# Altium schematic sheet Data-Power.SchDoc
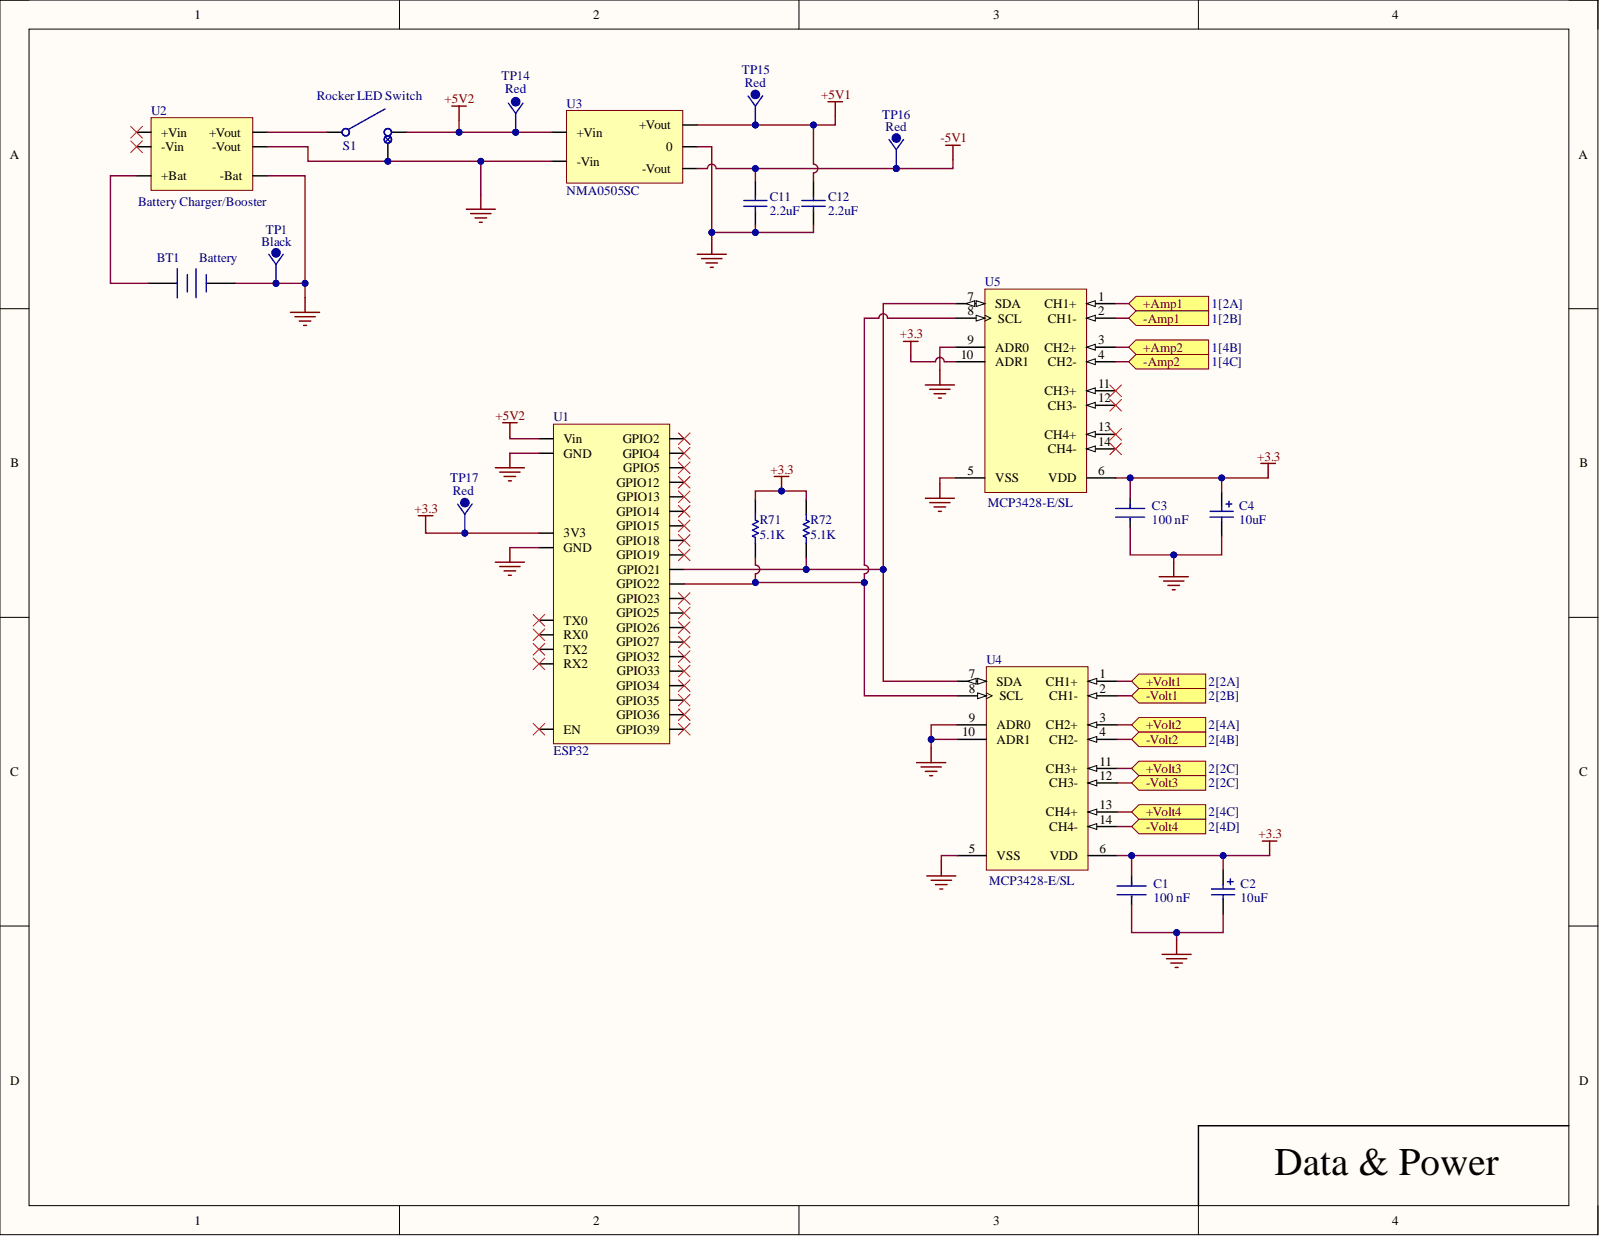
[diagram p0: Data-Power.SchDoc]

## Parts
BT1  Battery  [BAT-2]
C1  100nF  value 100 nF  [FP-CC0805-0_85-MFG]
C2  value 10uF  [0805 Tant Cap]  (hidden comment: TCTP1C106M8R)
C3  100nF  value 100 nF  [FP-CC0805-0_85-MFG]
C4  value 10uF  [0805 Tant Cap]  (hidden comment: TCTP1C106M8R)
C11  2.2uF  [CAPC2013X135X50ML10T20]
C12  2.2uF  [CAPC2013X135X50ML10T20]
R71  value 5.1K  [0805 Res]  (hidden comment: 5.1k Ohm)
R72  value 5.1K  [0805 Res]  (hidden comment: 5.1k Ohm)
S1  Rocker LED Switch  [SW]
TP1  Color: Black  [BlackTP]  (hidden comment: TP (Black))
TP14  Color: Red  [RedTP]  (hidden comment: TP (Red))
TP15  Color: Red  [RedTP]  (hidden comment: TP (Red))
TP16  Color: Red  [RedTP]  (hidden comment: TP (Red))
TP17  Color: Red  [RedTP]  (hidden comment: TP (Red))
U1  ESP32  [ESP32]
U2  Battery Charger/Booster  [Batt Chrg/Bst]
U3  DC DC Converter  [NMA0505SC]  (value not drawn)
U4  Manufacturer Part Number: MCP3428-E/SL  [SOIC-SL14_N]  (hidden comment: 4Ch ADC)
U5  Manufacturer Part Number: MCP3428-E/SL  [SOIC-SL14_N]  (hidden comment: 4Ch ADC)

## Ports
+Amp1, +Amp2, +Volt1, +Volt2, +Volt3, +Volt4, -Amp1, -Amp2, -Volt1, -Volt2, -Volt3, -Volt4

## Nets
+3.3: C1.1 C2.1 C3.1 C4.1 R71.2 R72.2 TP17.1 U1. U4.6(VDD) U5.6(VDD) U5.10(ADR1)
+5V1: C12.2 TP15.1 U3.
+5V2: S1. TP14.1 U1. U3.
-5V1: C11.2 TP16.1 U3.
GND: BT1.2 C1.2 C2.2 C3.2 C4.2 C11.1 C12.1 S1. TP1.1 U1. U2. U3. U4.5(VSS) U4.9(ADR0) U4.10(ADR1) U5.5(VSS) U5.9(ADR0)
NetS1: S1. U2.
NetU2: U2.
NetBT1_1: BT1.1 U2.
NetR71_1: R71.1 U1.39(GPIO22) U4.8(SCL) U5.8(SCL)
NetR72_1: R72.1 U1.42(GPIO21) U4.7(SDA) U5.7(SDA)
NetU4_1: U4.1(CH1+)
NetU4_2: U4.2(CH1-)
NetU4_3: U4.3(CH2+)
NetU4_4: U4.4(CH2-)
NetU4_11: U4.11(CH3+)
NetU4_12: U4.12(CH3-)
NetU4_13: U4.13(CH4+)
NetU4_14: U4.14(CH4-)
NetU5_1: U5.1(CH1+)
NetU5_2: U5.2(CH1-)
NetU5_3: U5.3(CH2+)
NetU5_4: U5.4(CH2-)

Not connected: U1 5(GPIO36) 8(GPIO39) 9(EN) 10(GPIO34) 11(GPIO35) 12(GPIO32) 13(GPIO33) 14(GPIO25) 15(GPIO26) 16(GPIO27) 17(GPIO14) 18(GPIO12) 20(GPIO13) 21(GPIO15) 22(GPIO2) 24(GPIO4) 25(RX2) 27(TX2) 34(GPIO5) 35(GPIO18) 36(GPIO23) 38(GPIO19) 40(RX0) 41(TX0); U5 11(CH3+) 12(CH3-) 13(CH4+) 14(CH4-)

## Library data of the parts
BT1 = Battery: 3.7V 1000mAh Battery with Protection Board; Manufacturer: Amazon(MakerFocus); Manufacturer Part Number: B07CXNQ3ZR; Component Kind: Standard; LatestRevisionDate: 17-Jul-2002; LatestRevisionNote: Re-released for DXP Platform.; Library Name: Miscellaneous Devices.IntLib; PackageDescription: Battery; 2 Leads; PackageReference: BAT-2; Published: 24-Mar-1999; Publisher: Altium Limited
C1 C3 = CMP-1036-04402-6: CAP CER 0.1UF 16V X7R 0805; Manufacturer: Yageo; Manufacturer Part Number: CC0805KRX7R7BB104; Material: Ceramic; Tolerance: 0.1; Automotive: No; Capacitance: 100 nF; Category: Cap; Component Kind: Standard; ComponentLink2Description: Datasheet; ComponentLink2URL: http://www.yageo.com/documents/recent/UPY-GPHC_Y5V_6.3V-to-50V_8.pdf; Device Class L1: Passive Components; Device Class L2: Capacitors; Device Class L3: Ceramic Capacitors; Digikey Description: CAP CER 0.1UF 16V X7R 0805; Footprint Url: http://www.yageo.com/exep/pages/download/literatures/UPY-C_GEN_24.pdf; Height: 0.95mm; Ipc Land Pattern Name: CAPC200125X85; Lead Free: Yes; Library Name: Altium Content Vault; Mouser Description: Multilayer Ceramic Capacitors MLCC - SMD/SMT 100nF 16V X7R 10%; Octopart: https://octopart.com/cc0805krx7r7bb104-yageo-39467769; Package: CC0805-0.85; RoHS: TRUE; Temperature: -30°C to +85°C; Temperature Characteristic: X7R; Temperature Range High: +85°C; Temperature Range Low: -30°C; Voltage Rating: 16 V
C2 C4 = Cap Pol2: Cap Tant 10UF 20% 16V 0805; Manufacturer: Kyocera AVX; Category: Tantalum Capacitors; Component Kind: Standard; ComponentLink1Description: https://datasheet.octopart.com/TCTP1C106M8R-KYOCERA-AVX-datasheet-165512328.pdf?src-supplier=Future+Electronics; ComponentLink1URL: https://datasheet.octopart.com/TCTP1C106M8R-KYOCERA-AVX-datasheet-165512328.pdf?src-supplier=Future+Electronics; LatestRevisionDate: 17-Jul-2002; LatestRevisionNote: Re-released for DXP Platform.; Library Name: Miscellaneous Devices.IntLib; Manufacturer Part Number: TCTP1C106M8R; PackageDescription: Polarized Capacitor; 2 Leads; PackageReference: POLAR0.8; Pricing 1: 1=0.8, 10=0.512, 50=0.3954, 100=0.3578, 500=0.29124, 1000=0.26947 (USD); Published: 8-Jun-2000; RoHS: No; Signal Integrity: Cap Pol; Simulation: CAP; Stock 1: 17395; Supplier 1: Digikey; Supplier Part Number 1: 478-TCTP1C106M8RCT-ND; Publisher: Altium Limited
C11 C12 = CMP-2007-03455-1: Ceramic Capacitor, Multilayer, Ceramic, 16V, 10% +Tol, 10% -Tol, X7R, 15% TC, 2.2uF, Surface Mount, 0805; Manufacturer: Murata; Manufacturer Part Number: GRM21BR71C225KA12L; Multilayer: Yes; Capacitor Type: Ceramic; Case/Package: 0805; Category: Ceramic Capacitors; Component Kind: Standard; ComponentLink1Description: https://datasheet.ciiva.com:443/pdfs/VipMasterIC/IC/MURE/MURE-S-A0004349797/MURE-S-A0004361718-1.pdf?src-supplier=IHS+Markit; ComponentLink1URL: https://datasheet.ciiva.com:443/pdfs/VipMasterIC/IC/MURE/MURE-S-A0004349797/MURE-S-A0004361718-1.pdf?src-supplier=IHS+Markit; Dielectric Material: Ceramic; Height: 1.25mm; JESD-609 Code: e3; Length: 2mm; Library Name: Altium Content Vault; Max Operating Temperature: 125°C; Min Operating Temperature: -55°C; Mounting Technology: Surface Mount; Package Shape: Rectangular; Package Style: SMTMeter; Packaging: Tape and Reel; Pins: 2; Pricing 1: 1=0.33, 10=0.141, 50=0.141, 100=0.095, 1000=0.07, 10000=0.054 (USD); Rated DC Voltage (URdc): 16V; Reach SVHC Compliant: Yes; RoHS: Yes; RoHS Compliant: Yes; Stock 1: 24749; Supplier 1: Mouser; Supplier Part Number 1: 81-GRM21BR71C225KA2L; Temperature Characteristics Code: X7R; Terminal Finish: Nickel; Termination: Wraparound; Tolerance  (fill in as +-): 10%; Tolerance (fill in as +-): 10%; Value: 2.2uF; Width: 1.25mm
R71 R72 = Res1: Fixed Resistor, Metal Glaze/thick Film, 0.125W, 5110ohm, 150V, 1% +/-Tol, 100ppm/Cel, Surface Mount, 0805; Manufacturer: Stackpole Electronics; Manufacturer Part Number: RMCF0805FT5K11; Package Height: 0.5mm; Package Length: 2mm; Package Width: 1.25mm; Power: 125mW; Rated Temperature: 70°C; Reference Standard: AEC-Q200; Temperature Coefficient (ppm/Cel): 100; Case/Package: 0805; Category: Chip SMD Resistors; Component Kind: Standard; ComponentLink1Description: https://datasheet.ciiva.com:443/pdfs/VipMasterIC/IC/SEIE/SEIE-S-A0009541969/SEIE-S-A0009541969-1.pdf?src-supplier=IHS+Markit; ComponentLink1URL: https://datasheet.ciiva.com:443/pdfs/VipMasterIC/IC/SEIE/SEIE-S-A0009541969/SEIE-S-A0009541969-1.pdf?src-supplier=IHS+Markit; ComponentLink2Description: https://datasheet.ciiva.com/15193/getdatasheetpartid-409010-15193607.pdf?src-supplier=Verical; ComponentLink2URL: https://datasheet.ciiva.com/15193/getdatasheetpartid-409010-15193607.pdf?src-supplier=Verical; Construction: Rectangular; JESD-609 Code: e3; LatestRevisionDate: 17-Jul-2002; LatestRevisionNote: Re-released for DXP Platform.; Library Name: Miscellaneous Devices.IntLib; Max Operating Temperature: 155°C; Min Operating Temperature: -55°C; Mounting Technology: Surface Mount; Package Shape: Rectangular; PackageDescription: Axial Device, Thru-Hole; 2 Leads; 0.3 in Pin Spacing; PackageReference: AXIAL-0.3; Packaging: Tape and Reel; Pins: 2; Pricing 1: 5000=0.00252, 10000=0.00248, 20000=0.00245, 30000=0.00241, 40000=0.00238 (USD); Published: 8-Jun-2000; Reach SVHC Compliant: Yes; Resistor Type: FIXED RESISTOR; RoHS: Yes; RoHS Compliant: Yes; Signal Integrity: Res; Simulation: RESISTOR; Stock 1: 255000; Supplier 1: Avnet; Supplier Part Number 1: RMCF0805FT5K11; Technology: METAL GLAZE/THICK FILM; Terminal Finish: Nickel; Termination: Wraparound; Tolerance: 1%; Working Voltage: 150V; Publisher: Altium Limited
S1 = SW: Single-Pole, Single-Throw Rocket LED Switch; Manufacturer: Amazon(Nilight); Manufacturer Part Number: B07T1JG6BD; Component Kind: Standard; Library Name: Schlib1.SchLib
TP1 = TP: Interconnection Device; Manufacturer: Keystone; Manufacturer P/N: 5001; Category: Connectors; Component Kind: Standard; ComponentLink1Description: https://datasheet.ciiva.com:443/pdfs/VipMasterIC/IC/KEYC/KEYCS01036/KEYCS02044-1.pdf?src-supplier=IHS+Markit; ComponentLink1URL: https://datasheet.ciiva.com:443/pdfs/VipMasterIC/IC/KEYC/KEYCS01036/KEYCS02044-1.pdf?src-supplier=IHS+Markit; ComponentLink2Description: https://datasheet.ciiva.com/11836/0900766b8009b7c7-11836045.pdf?src-supplier=Rs; ComponentLink2URL: https://datasheet.ciiva.com/11836/0900766b8009b7c7-11836045.pdf?src-supplier=Rs; ComponentLink3Description: https://datasheet.ciiva.com/27052/1581986-27052611.pdf?src-supplier=Jameco; ComponentLink3URL: https://datasheet.ciiva.com/27052/1581986-27052611.pdf?src-supplier=Jameco; ComponentLink4Description: https://datasheet.ciiva.com/22631/1703983-22631100.pdf?src-supplier=Element14; ComponentLink4URL: https://datasheet.ciiva.com/22631/1703983-22631100.pdf?src-supplier=Element14; ComponentLink5Description: https://datasheet.ciiva.com/16318/m60-2p60-16318423.pdf?src-supplier=Future+Electronics; ComponentLink5URL: https://datasheet.ciiva.com/16318/m60-2p60-16318423.pdf?src-supplier=Future+Electronics; Connector Type: Interconnection Device; Contact Finish - Termination: Silver (Ag); JESD-609 Code: e4; Manufacturer Part Number: 5001; Pricing 1: 1=0.29, 10=0.29, 25=0.262, 50=0.262, 100=0.222, 500=0.184, 1000=0.173, 2500=0.154, 5000=0.143, 10000=0.143 (USD); Reach SVHC Compliant: Yes; RoHS: Yes; RoHS Compliant: Yes; Stock 1: 345950; Supplier 1: Mouser; Supplier Part Number 1: 534-5001
TP14-TP17 = TP: Interconnection Device; Manufacturer: Keystone; Manufacturer Part Number: 5000; Category: Connectors; Component Kind: Standard; ComponentLink1Description: https://datasheet.ciiva.com:443/pdfs/VipMasterIC/IC/KEYC/KEYCS01036/KEYCS02044-1.pdf?src-supplier=IHS+Markit; ComponentLink1URL: https://datasheet.ciiva.com:443/pdfs/VipMasterIC/IC/KEYC/KEYCS01036/KEYCS02044-1.pdf?src-supplier=IHS+Markit; ComponentLink2Description: https://datasheet.ciiva.com/11836/0900766b8009b7c7-11836046.pdf?src-supplier=Rs; ComponentLink2URL: https://datasheet.ciiva.com/11836/0900766b8009b7c7-11836046.pdf?src-supplier=Rs; Connector Type: Interconnection Device; Contact Finish - Termination: Silver (Ag); JESD-609 Code: e4; Pricing 1: 1=0.29, 10=0.29, 50=0.262, 100=0.222, 1000=0.173, 10000=0.143 (USD); Reach SVHC Compliant: Yes; RoHS: Yes; RoHS Compliant: Yes; Stock 1: 320297; Supplier 1: Mouser; Supplier Part Number 1: 534-5000
U1 = ESP32: ESP-WROOM-32 ESP32 ESP-32S Development Board 2.4GHz Dual-Mode WiFi + Bluetooth Dual Cores Microcontroller Processor Integrated with Antenna RF AMP Filter AP STA; Manufacturer: Amazon(AITRIP); Manufacturer Part Number: B08D5ZD528; Component Kind: Standard; Library Name: Schlib1.SchLib
U2 = Booster/Charger: Lithium Battery Fast Charging Boost Mobile Power Protection PCB Board; Manufacturer: Amazon(AEDIKO); Manufacturer Part Number: B09X9VMGV4; Component Kind: Standard; Library Name: Schlib1.SchLib
U3 = NMA0505SC: DC DC CONVERTER +/-5V 1W; Manufacturer: Murata; Component Kind: Standard; Library Name: Schlib1.SchLib; Manufacturer Part Number: NMA0505SC
U4 = CMP-0188-01159-1: 16-Bit, Multi-Channel Delta-Sigma Analog-to-Digital Converter with I2C Interface and On-Board Reference, 14-Pin SOIC 150mil, Extended Temperature; Manufacturer: Microchip Tech; Address: 000; Code_IPC: SOIC127P600-14; Code_JEDEC: MS-012-AB; Component Kind: Standard; ComponentLink1Description: Manufacturer URL; ComponentLink1URL: http://www.microchip.com/; ComponentLink2Description: Datasheet; ComponentLink2URL: http://ww1.microchip.com/downloads/en/DeviceDoc/22226a.pdf; ComponentLink3Description: Package Specification; ComponentLink3URL: http://www.microchip.com/stellent/groups/techpub_sg/documents/packagingspec/en012702.pdf; DatasheetVersion: revA, Dec-2009; Library Name: Altium Content Vault; PackageDescription: 14-Lead Plastic Small Outline (SL) - Narrow, 3.90mm Body [SOIC]; PackageReference: SOIC-SL14; PackageVersion: revBB, Aug-2009
U5 = CMP-0188-01159-1: 16-Bit, Multi-Channel Delta-Sigma Analog-to-Digital Converter with I2C Interface and On-Board Reference, 14-Pin SOIC 150mil, Extended Temperature; Manufacturer: Microchip Tech; Address: 010; Code_IPC: SOIC127P600-14; Code_JEDEC: MS-012-AB; Component Kind: Standard; ComponentLink1Description: Manufacturer URL; ComponentLink1URL: http://www.microchip.com/; ComponentLink2Description: Datasheet; ComponentLink2URL: http://ww1.microchip.com/downloads/en/DeviceDoc/22226a.pdf; ComponentLink3Description: Package Specification; ComponentLink3URL: http://www.microchip.com/stellent/groups/techpub_sg/documents/packagingspec/en012702.pdf; DatasheetVersion: revA, Dec-2009; Library Name: Altium Content Vault; PackageDescription: 14-Lead Plastic Small Outline (SL) - Narrow, 3.90mm Body [SOIC]; PackageReference: SOIC-SL14; PackageVersion: revBB, Aug-2009
